# Altium schematic sheet sdram_sram.SchDoc
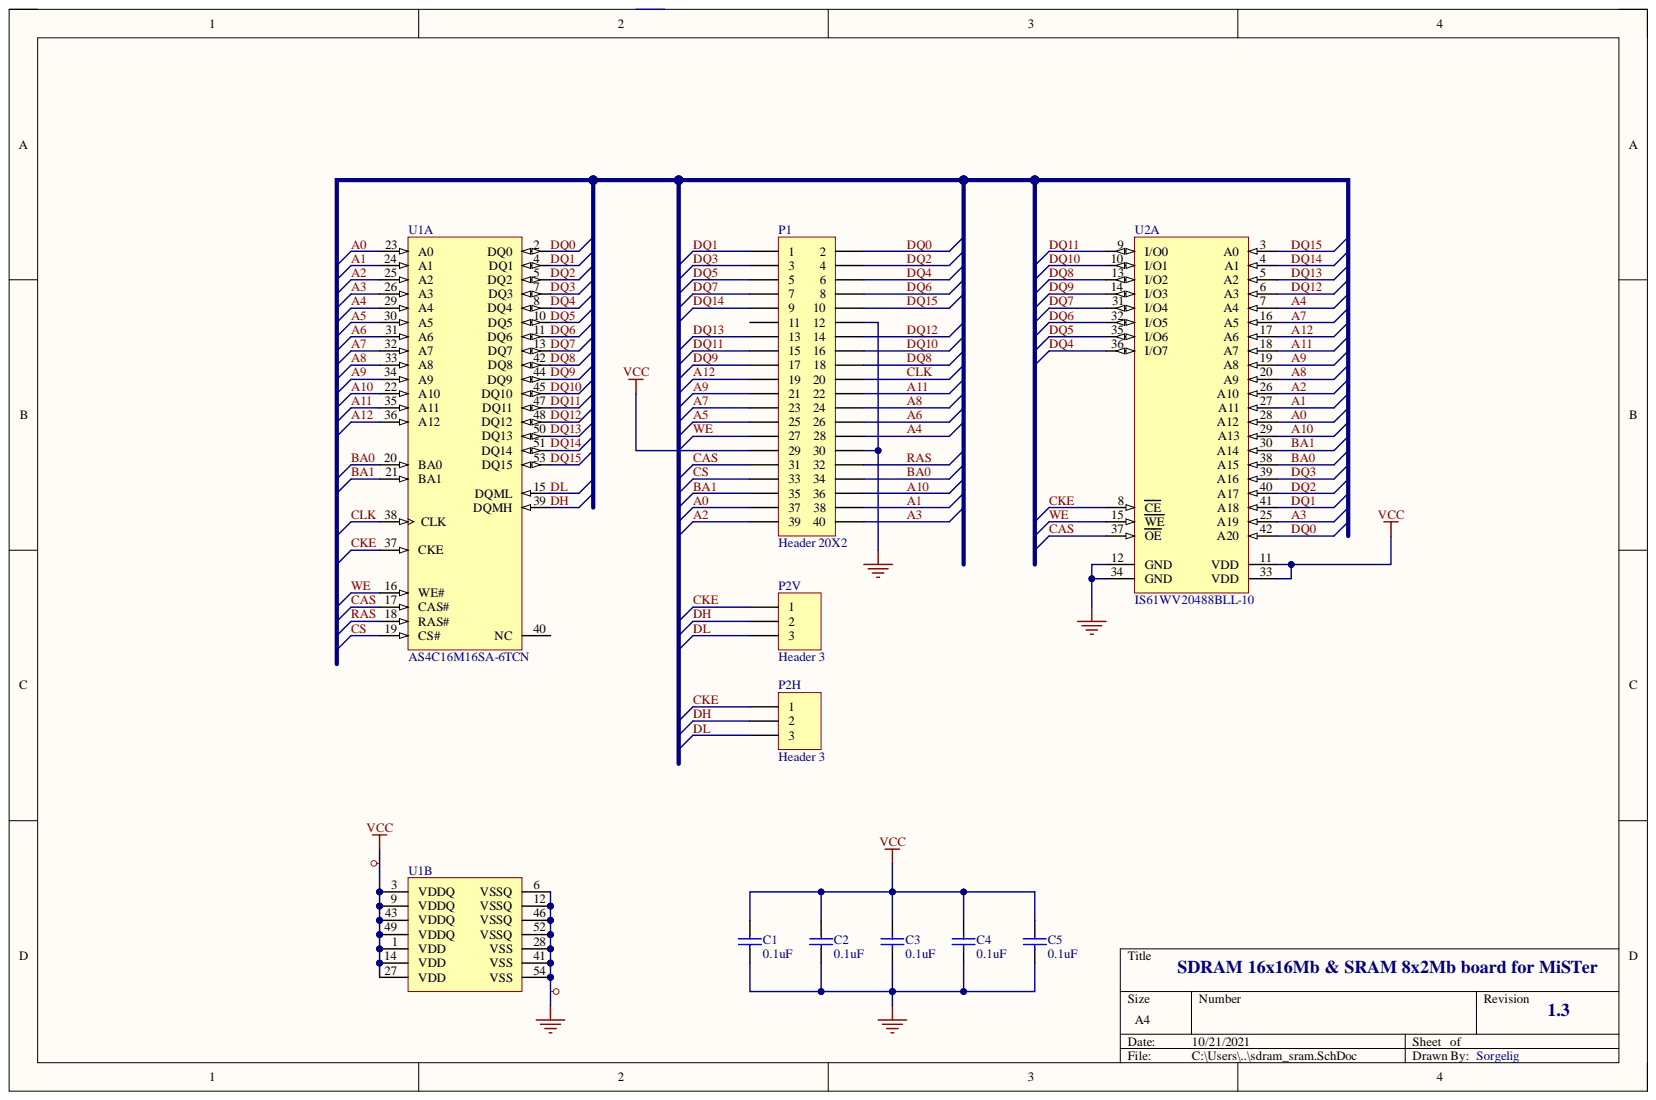
[diagram p0: sdram_sram.SchDoc]

## Parts
C1  value 0.1uF  [C0805]  (hidden comment: C0805)
C2  value 0.1uF  [C0805]  (hidden comment: C0805)
C3  value 0.1uF  [C0805]  (hidden comment: +)
C4  value 0.1uF  [C0805]  (hidden comment: C0805)
C5  value 0.1uF  [C0805]  (hidden comment: +)
P1  Header 20X2  [HDR20x2_90]
P2H  Header 3  [HDR1X3]
P2V  Header 3  [HDR1X3]
U1  AS4C16M16SA-6TCN  [TSOP54]  (parts A,B)
U2  IS61WV20488BLL-10  [ISSI-TSOP-2-44_N]  (part A)

## Nets
GND: C1.1 C2.1 C3.1 C4.1 C5.1 P1.12 P1.30 U1.6(VSSQ) U1.12(VSSQ) U1.28(VSS) U1.41(VSS) U1.46(VSSQ) U1.52(VSSQ) U1.54(VSS) U2.12(GND) U2.34(GND)
VCC: C1.2 C2.2 C3.2 C4.2 C5.2 P1.29 U1.1(VDD) U1.3(VDDQ) U1.9(VDDQ) U1.14(VDD) U1.27(VDD) U1.43(VDDQ) U1.49(VDDQ) U2.11(VDD) U2.33(VDD)
A0: P1.37 U1.23(A0) U2.28(A12)
A1: P1.38 U1.24(A1) U2.27(A11)
A2: P1.39 U1.25(A2) U2.26(A10)
A3: P1.40 U1.26(A3) U2.25(A19)
A4: P1.28 U1.29(A4) U2.7(A4)
A5: P1.25 U1.30(A5)
A6: P1.26 U1.31(A6)
A7: P1.23 U1.32(A7) U2.16(A5)
A8: P1.24 U1.33(A8) U2.20(A9)
A9: P1.21 U1.34(A9) U2.19(A8)
A10: P1.36 U1.22(A10) U2.29(A13)
A11: P1.22 U1.35(A11) U2.18(A7)
A12: P1.19 U1.36(A12) U2.17(A6)
BA0: P1.34 U1.20(BA0) U2.38(A15)
BA1: P1.35 U1.21(BA1) U2.30(A14)
CAS: P1.31 U1.17(CAS#) U2.37(OE)
CKE: P2H.1 P2V.1 U1.37(CKE) U2.8(CE)
CLK: P1.20 U1.38(CLK)
CS: P1.33 U1.19(CS#)
DH: P2H.2 P2V.2 U1.39(DQMH)
DL: P2H.3 P2V.3 U1.15(DQML)
DQ0: P1.2 U1.2(DQ0) U2.42(A20)
DQ1: P1.1 U1.4(DQ1) U2.41(A18)
DQ2: P1.4 U1.5(DQ2) U2.40(A17)
DQ3: P1.3 U1.7(DQ3) U2.39(A16)
DQ4: P1.6 U1.8(DQ4) U2.36(I/O7)
DQ5: P1.5 U1.10(DQ5) U2.35(I/O6)
DQ6: P1.8 U1.11(DQ6) U2.32(I/O5)
DQ7: P1.7 U1.13(DQ7) U2.31(I/O4)
DQ8: P1.18 U1.42(DQ8) U2.13(I/O2)
DQ9: P1.17 U1.44(DQ9) U2.14(I/O3)
DQ10: P1.16 U1.45(DQ10) U2.10(I/O1)
DQ11: P1.15 U1.47(DQ11) U2.9(I/O0)
DQ12: P1.14 U1.48(DQ12) U2.6(A3)
DQ13: P1.13 U1.50(DQ13) U2.5(A2)
DQ14: P1.9 U1.51(DQ14) U2.4(A1)
DQ15: P1.10 U1.53(DQ15) U2.3(A0)
RAS: P1.32 U1.18(RAS#)
WE: P1.27 U1.16(WE#) U2.15(WE)
N00000:

Not connected: P1 11; U1 40(NC)

## Library data of the parts
C1-C5 = C0805: Ceramic Chip Capacitor - Standard; Note: Footprint corresponds to dimensions for bulk cassette packaging. This case size has tightened tolerances.; Code_IPC: 2012[0805]; Code_JEITA: 0805; Component Kind: Standard; ComponentLink1Description: Manufacturer Link; ComponentLink1URL: http://www.kemet.com/; ComponentLink2Description: Datasheet; ComponentLink2URL: http://www.kemet.com/kemet/web/homepage/kechome.nsf/vapubfilesname/F3102TA.pdf/$file/F3102TA.pdf; DatasheetDocument: Mar-2001; LatestRevisionDate: 19-Mar-2004; LatestRevisionNote: Silkscreen size reduced.; Library Name: lib.PcbLib; PackageDocument: Mar-2001; PackageReference: 0805; Published: 17-Jul-2002; Signal Integrity: C0805; Simulation: CAP; Publisher: Altium Limited
P1 = Header 20X2: Header, 20-Pin, Dual row; Component Kind: Standard; LatestRevisionDate: 17-Jul-2002; LatestRevisionNote: Re-released for DXP Platform.; Library Name: Miscellaneous Connectors.IntLib; Signal Integrity: Connector; Publisher: Altium Limited
P2H P2V = Header 3: Header, 3-Pin; Component Kind: Standard; LatestRevisionDate: 17-Jul-2002; LatestRevisionNote: Re-released for DXP Platform.; Library Name: Miscellaneous Connectors.IntLib; Signal Integrity: Connector; Publisher: Altium Limited
U1 = AS4C16M16SA-6TCN: 256Mb SDR SDRAM, 16Mb x 16, 6ns, 3.3V, 54-Pin TSOP; Manufacturer: Micron; PartNumber: MT48LC16M16A2P-6A; CL: CL = 3; Clock Rate: 167 MHz; Data Rate: PC133; Op. Temp.: 0C to +70C; Component Kind: Standard; ComponentLink1Description: Manufacturer URL; ComponentLink1URL: http://www.micron.com/; ComponentLink2Description: Datasheet; ComponentLink2URL: http://www.micron.com/~/media/Documents/Products/Data%20Sheet/DRAM/256Mb_sdr.pdf; ComponentLink3Description: Package Specification; ComponentLink3URL: http://www.micron.com/~/media/Documents/Products/Data%20Sheet/DRAM/128mb_x4x8x16_sdram.pdf; Cycle Time: 6ns; DatasheetVersion: Rev.Q; Density: 256Mb; Depth: 16Mb; Library Name: lib.SchLib; Mounting Technology: Surface Mount; PackageDescription: 54-Pin Thin Small Outline Package, 10.16 x 22.22 mm, Pitch 0.8 mm; PackageReference: MICT-P-54; PackageVersion: Rev.Q; RoHS: Yes; Voltage: 3.3V; Width: x16
U2 = IS61WV20488BLL-10TI: 16 M High Speed CMOS SRAM, 2 M x 8, -40 to 85 degC, 44-Pin SOP (TSOP-2-44); Manufacturer: Integrated Silicon Solution Inc (ISSI); Organization: 2M x 8; Packing: Tray / Tube; Speed (ns): 10; Component Kind: Standard; ComponentLink1Description: Manufacturer URL; ComponentLink1URL: http://www.issi.com/US/index.shtml; ComponentLink2Description: Datasheet; ComponentLink2URL: http://www.issi.com/WW/pdf/61-64WV20488.pdf; ComponentLink3Description: Package Specification; ComponentLink3URL: http://www.issi.com/WW/pdf/61-64WV6416EEBLL.pdf; DatasheetVersion: Rev. B; Mounting Technology: Surface Mount; PackageDescription: 44-Pin 400 mil TSOP-2, Body 18.41 x 10.16 mm, Pitch 0.8 mm; PackageReference: TSOP-2-44; PackageVersion: Rev. F, 06/2008; PartNumber: IS61WV20488BLL-10TI; Library Name: ISSI SRAM Asynchronous SRAM High Speed Low Power.IntLib
